# Altium schematic sheet 3A03xx_Oxocard_Mini_Basic_AUDIO.SchDoc
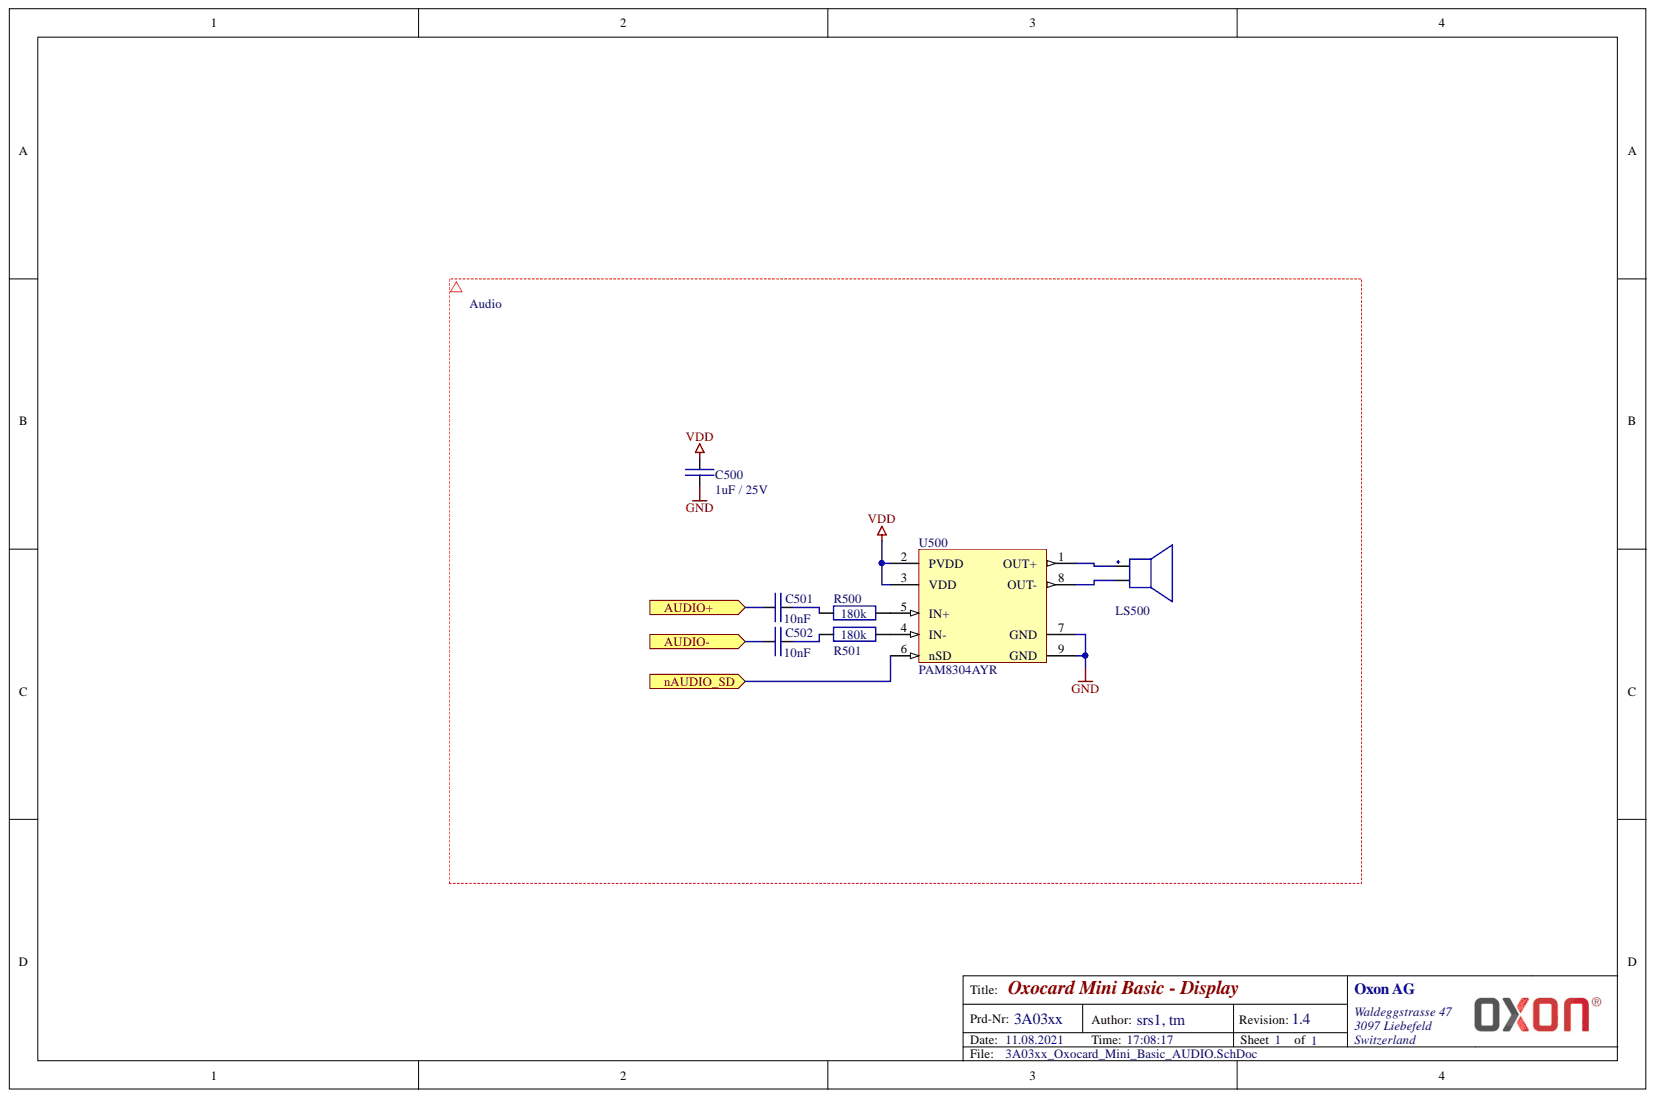
[diagram p0: 3A03xx_Oxocard_Mini_Basic_AUDIO.SchDoc]

## Parts
C500  1uF / 25V  [CAPC1005X60]
C501  10nF  [CAPC1005X60]
C502  10nF  [CAPC1005X60]
LS500  LS-Speaker_15x11mm  [Speaker-15x11mm]  (value not drawn)
R500  180k  [RESC1005X40]
R501  180k  [RESC1005X40]
U500  PAM8304AYR  [DFN8 3x3]

## Ports
AUDIO+, AUDIO-, nAUDIO_SD

## Nets
GND: C500.1 U500.7(GND) U500.9(GND)
VDD: C500.2 U500.2(PVDD) U500.3(VDD)
nAUDIO_SD: U500.6(nSD) ; port nAUDIO_SD
NetC501_1: C501.1 R500.1
NetC501_2: C501.2
NetC502_1: C502.1 R501.1
NetC502_2: C502.2
NetLS500_N: LS500.N U500.8(OUT-)
NetLS500_P: LS500.P U500.1(OUT+)
NetR500_2: R500.2 U500.5(IN+)
NetR501_2: R501.2 U500.4(IN-)

## Library data of the parts
C500 = C-CAP0402_1u: SMD capacitor 25V 10%; Manufacturer: Wurth Electronics; Manufacturer Designator: GRM155R61E105KA12J; Component Kind: Standard; Distributor: Mouser; Distributor Part-Number: 81-GRM155R61E105KA12J; Library Name: XLib.SCHLIB
C501 C502 = C-CAP0402_10n: SMD capacitor 25V 5%; Manufacturer: Yageo; Manufacturer Designator: GCM155R71E103JA7D; Component Kind: Standard; Distributor: Mouser; Distributor Part-Number: 81-GCM155R71E103JA7D; Library Name: XLib.SCHLIB
LS500 = LS-Speaker_15x11mm: Speaker 15x11mm spring contact; Component Kind: Standard; Distributor: China; Library Name: XLib.SCHLIB
R500 R501 = R-RES0402_180k: SMD resistor 1%; Manufacturer: Yageo; Manufacturer Designator: AF0402FR-07180KL; Component Kind: Standard; Distributor: Mouser; Distributor Part-Number: 603-AF0402FR-07180KL; Library Name: XLib.SCHLIB
U500 = U-PAM8304AYR: Class D Audio Amplifiers; Manufacturer: Diodes Incorporated; Component Kind: Standard; Distributor: Mouser; Distributor Part-Number: 621-PAM8304AYR; Library Name: XLib.SCHLIB; Manufacturer Designator: PAM8304AYR
